# Altium schematic sheet typec_16pin.SchDoc
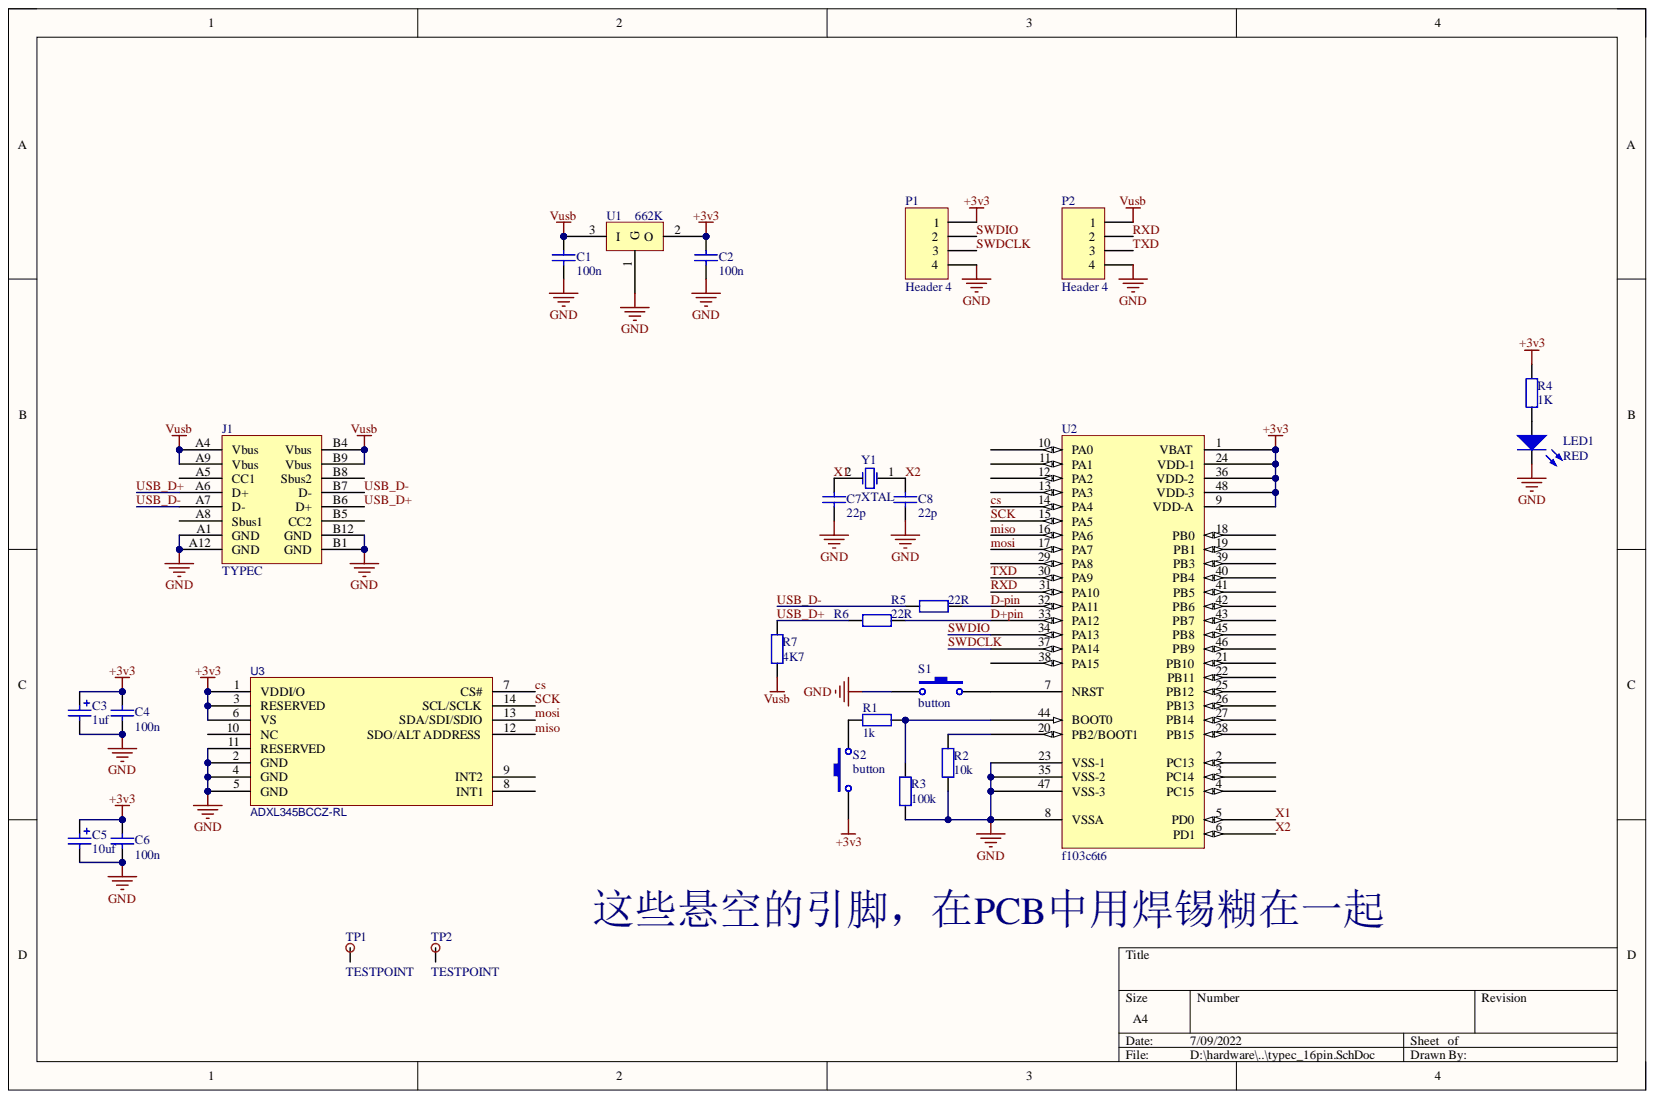
[diagram p0: typec_16pin.SchDoc]

## Parts
C1  100n  [0603C]
C2  100n  [0603C]
C3  1uf  [0805T]
C4  100n  [0603C]
C5  10uf  [0805T]
C6  100n  [0603C]
C7  22p  [0603C]
C8  22p  [0603C]
J1  TYPEC  [TYPEC16P_SMT_THT]
LED1  RED  [0805d_red]
P1  Header 4  [HDR1X4]
P2  Header 4  [HDR1X4]
R1  1k  [0603r]
R2  10k  [0603r]
R3  100k  [0603r]
R4  1K  [0603r]
R5  22R  [0603r]
R6  22R  [0603r]
R7  4K7  [0603r]
S1  button  [BTN3X4]
S2  button  [BTN3X4]
TP1  TESTPOINT  [PAD2X4]
TP2  TESTPOINT  [PAD2X4]
U1  662K  [SOT95P280X130-3N]
U2  f103c6t6  [TSQFP50P900X900X160-48M]
U3  ADXL345BCCZ-RL  [LGA-14_L5.0-W3.0-P0.80-BL-1]
Y1  XTAL  [XTAL5032N_2P]

## Nets
+3v3: C2.2 C3.1 C4.2 C5.1 C6.2 P1.1 R4.2 S2.1 U1.2 U2.1(VBAT) U2.9(VDD-A) U2.24(VDD-1) U2.36(VDD-2) U2.48(VDD-3) U3.1(VDDI/O) U3.3(RESERVED) U3.6(VS)
GND: C1.1 C2.1 C3.2 C4.1 C5.2 C6.1 C7.1 C8.1 J1.A1(GND) J1.A12(GND) J1.B1(GND) J1.B12(GND) LED1.2 P1.4 P2.4 R2.2 R3.2 S1.1 U1.1 U2.8(VSSA) U2.23(VSS-1) U2.35(VSS-2) U2.47(VSS-3) U3.2(GND) U3.4(GND) U3.5(GND) U3.11(RESERVED)
Vusb: C1.2 J1.A4(Vbus) J1.A9(Vbus) J1.B4(Vbus) J1.B9(Vbus) P2.1 R7.2 U1.3
cs: U2.14(PA4) U3.7(CS#)
D+pin: R6.1 U2.33(PA12)
D-pin: R5.1 U2.32(PA11)
miso: U2.16(PA6) U3.12(SDO/ALT_ADDRESS)
mosi: U2.17(PA7) U3.13(SDA/SDI/SDIO)
RXD: P2.2 U2.31(PA10)
SCK: U2.15(PA5) U3.14(SCL/SCLK)
SWDCLK: P1.3 U2.37(PA14)
SWDIO: P1.2 U2.34(PA13)
TXD: P2.3 U2.30(PA9)
USB_D+: J1.A6(D+) J1.B6(D+) R6.2 R7.1
USB_D-: J1.A7(D-) J1.B7(D-) R5.2
X1: C7.2 U2.5(PD0) Y1.2
X2: C8.2 U2.6(PD1) Y1.1
NetLED1_1: LED1.1 R4.1
NetR1_1: R1.1 S2.2
NetR1_2: R1.2 R3.1 U2.44(BOOT0)
NetR2_1: R2.1 U2.20(PB2/BOOT1)
NetS1_2: S1.2 U2.7(NRST)

Not connected: J1 A5(CC1) A8(Sbus1) B5(CC2) B8(Sbus2); TP1 1; TP2 1; U2 2(PC13) 3(PC14) 4(PC15) 10(PA0) 11(PA1) 12(PA2) 13(PA3) 18(PB0) 19(PB1) 21(PB10) 22(PB11) 25(PB12) 26(PB13) 27(PB14) 28(PB15) 29(PA8) 38(PA15) 39(PB3) 40(PB4) 41(PB5) 42(PB6) 43(PB7) 45(PB8) 46(PB9); U3 8(INT1) 9(INT2) 10(NC)

## Library data of the parts
C1 C2 C4 C6-C8 = Cap: 无极性定值电容; Component Kind: Standard; LatestRevisionDate: 17-Jul-2002; LatestRevisionNote: Re-released for DXP Platform.; Library Name: 常用.SchLib; PackageDescription: Radial Cap, Thru-Hole; 2 Leads; 0.3 in Pin Spacing; PackageReference: RAD-0.3; Published: 8-Jun-2000; Signal Integrity: Cap; Simulation: CAP; Publisher: Altium Limited
C3 C5 = Cap Pol3: 有极性电容 贴片; Component Kind: Standard; LatestRevisionDate: 29-May-2009; LatestRevisionNote: IPC-7351 Footprint Added.; Library Name: 常用.SchLib; PackageDescription: Chip Capacitor; PackageReference: C0805; Published: 8-Jun-2000; Signal Integrity: Cap Pol; Simulation: CAP; Publisher: Altium Limited
J1 = TypeC_16PIN: Component Kind: Standard; Library Name: 接插头.SchLib
LED1 = LED0: 普通LED; Component Kind: Standard; LatestRevisionDate: 08-Jul-2005; LatestRevisionNote: Mech Layer 1 removed.; Library Name: 常用.SchLib; PackageDescription: LED; 2 Leads; PackageReference: LED-0; Simulation: LED0; Value: RED; Publisher: Altium Limited
P1 P2 = Header 4: Header, 4-Pin; Component Kind: Standard; LatestRevisionDate: 17-Jul-2002; LatestRevisionNote: Re-released for DXP Platform.; Signal Integrity: Connector; Library Name: 通用接插头符号.SchLib; Publisher: Altium Limited
R1-R7 = Res2: 定值电阻; Component Kind: Standard; LatestRevisionDate: 17-Jul-2002; LatestRevisionNote: Re-released for DXP Platform.; PackageDescription: Axial Device, Thru-Hole; 2 Leads; 0.4 in Pin Spacing; PackageReference: AXIAL-0.4; Published: 8-Jun-2000; Signal Integrity: Res; Simulation: RESISTOR; Publisher: Altium Limited
  R4: Library Name: 常用.SchLib
  R1-R3 R5-R7: Library Name: 通用元件库.SchLib
S1 S2 = SW-PB: 轻触开关; Component Kind: Standard; LatestRevisionDate: 17-Jul-2002; LatestRevisionNote: Re-released for DXP Platform.; PackageDescription: Switch; 2 Leads; PackageReference: SPST-2; Published: 24-Mar-1999; Library Name: 通用元件库.SchLib; Publisher: Altium Limited
U1 = XC6206P302MR: 3.0v ldo 400MA 0.4v压降; Component Kind: Standard; Library Name: 电源芯片.SchLib
U2 = stm32f103c6t6: Component Kind: Standard; Library Name: 常用.SchLib
U3 = ADXL345BCCZ-RL: BOM_Manufacturer: ADI(亚德诺)/LINEAR; Contributor: LCSC; BOM_JLCPCB Part Class: Extended Part; BOM_Manufacturer Part: ADXL345BCCZ-RL; BOM_Supplier: LCSC; BOM_Supplier Part: C579457; Component Kind: Standard; Library Name: 常用.SchLib; spicePre: U; spiceSymbolName: ADXL345BCCZ-RL
Y1 = XTAL_Passive_2P: 无源晶振; Component Kind: Standard; LatestRevisionDate: 17-Jul-2002; LatestRevisionNote: Re-released for DXP Platform.; Library Name: 常用.SchLib; PackageDescription: Crystal, Thru-Hole; 2 Leads; Body 3.1 x 8.2 mm (Dia.xH); PackageReference: R38; Published: 8-Jun-2000; Publisher: Altium Limited
TP1 TP2 = TESTPOINT: Component Kind: Standard; Library Name: 常用.SchLib
